# Altium schematic sheet YYB_2016.SchDoc
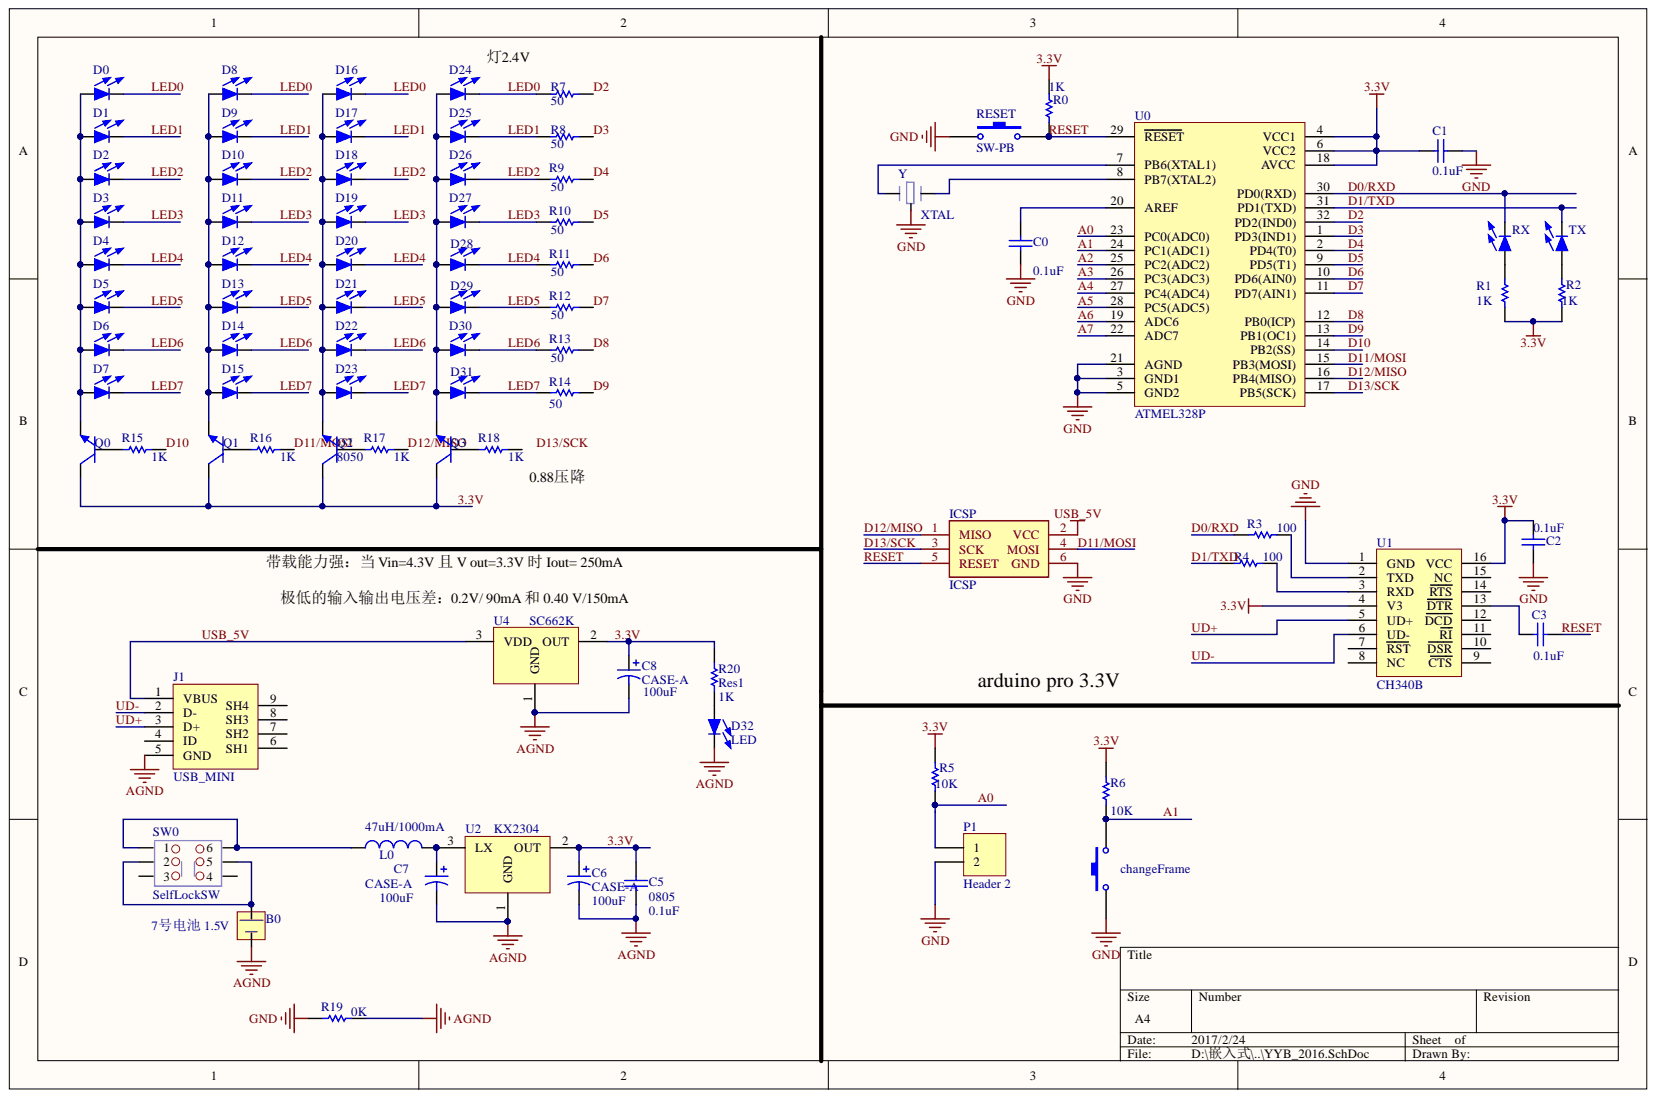
[diagram p0: YYB_2016.SchDoc]

## Parts
B0  7号电池 1.5V  [BAT]  (value not drawn)
C0  value 0.1uF  [6-0805_N]
C1  value 0.1uF  [6-0805_N]  (hidden comment: Cap)
C2  value 0.1uF  [6-0805_N]  (hidden comment: Cap)
C3  value 0.1uF  [6-0805_N]  (hidden comment: Cap)
C5  0805  value 0.1uF  [6-0805_N]
C6  CASE-A  value 100uF  [CASE-A_3216]
C7  CASE-A  value 100uF  [CASE-A_3216]
C8  CASE-A  value 100uF  [CASE-A_3216]
changeFrame  SW-PB  [KEY_SODFL_2-Leads]  (value not drawn)
D0  (no value)  [LED_3528]
D1  (no value)  [LED_3528]
D2  (no value)  [LED_3528]
D3  (no value)  [LED_3528]
D4  (no value)  [LED_3528]
D5  (no value)  [LED_3528]
D6  (no value)  [LED_3528]
D7  (no value)  [LED_3528]
D8  (no value)  [LED_3528]
D9  (no value)  [LED_3528]
D10  (no value)  [LED_3528]
D11  (no value)  [LED_3528]
D12  (no value)  [LED_3528]
D13  (no value)  [LED_3528]
D14  (no value)  [LED_3528]
D15  (no value)  [LED_3528]
D16  (no value)  [LED_3528]
D17  (no value)  [LED_3528]
D18  (no value)  [LED_3528]
D19  (no value)  [LED_3528]
D20  (no value)  [LED_3528]
D21  (no value)  [LED_3528]
D22  (no value)  [LED_3528]
D23  (no value)  [LED_3528]
D24  (no value)  [LED_3528]
D25  (no value)  [LED_3528]
D26  (no value)  [LED_3528]
D27  (no value)  [LED_3528]
D28  (no value)  [LED_3528]
D29  (no value)  [LED_3528]
D30  (no value)  [LED_3528]
D31  (no value)  [LED_3528]
D32  LED  [LED_0805]
ICSP  ICSP  [HDR2X3]
J1  USB_MINI  [MICRO_USB]
L0  value 47uH/1000mA  [0402-A]  (hidden comment: Inductor)
P1  Header 2  [HDR1X2]
Q0  transistor  [SOT-23]  (value not drawn)
Q1  transistor  [SOT-23]  (value not drawn)
Q2  8050  [SOT-23]
Q3  transistor  [SOT-23]  (value not drawn)
R0  value 1K  [6-0805_N]
R1  value 1K  [6-0805_N]  (hidden comment: Res1)
R2  value 1K  [6-0805_N]  (hidden comment: Res1)
R3  value 100  [6-0805_N]  (hidden comment: Res1)
R4  value 100  [6-0805_N]  (hidden comment: Res1)
R5  value 10K  [6-0805_N]  (hidden comment: Res1)
R6  value 10K  [6-0805_N]  (hidden comment: Res1)
R7  value 50  [6-0805_N]  (hidden comment: Res1)
R8  value 50  [6-0805_N]  (hidden comment: Res1)
R9  value 50  [6-0805_N]  (hidden comment: Res1)
R10  value 50  [6-0805_N]  (hidden comment: Res1)
R11  value 50  [6-0805_N]  (hidden comment: Res1)
R12  value 50  [6-0805_N]  (hidden comment: Res1)
R13  value 50  [6-0805_N]  (hidden comment: Res1)
R14  value 50  [6-0805_N]  (hidden comment: Res1)
R15  value 1K  [6-0805_N]  (hidden comment: Res1)
R16  value 1K  [6-0805_N]  (hidden comment: Res1)
R17  value 1K  [6-0805_N]  (hidden comment: Res1)
R18  value 1K  [6-0805_N]  (hidden comment: Res1)
R19  value 0K  [6-0805_N]  (hidden comment: Res1)
R20  Res1  value 1K  [6-0805_N]
RESET  SW-PB  [KEY_SODFL_2-Leads]
RX  (no value)  [LED_0805]
SW0  SelfLockSW  [KEY_ZS_8*8]
TX  (no value)  [LED_0805]
U0  ATMEL328P  [TQFP 32]
U1  CH340B  [SOP16]
U2  KX2304  [SOT89N]
U4  SC662K  [SOT-23]
Y  XTAL  [XTAL]

## Nets
AGND: B0.2 C5.1 C6.2 C7.2 C8.2 D32.2 J1.5(GND) R19.2 U2.1 U4.1
GND: C0.1 C1.1 C2.1 changeFrame.1 ICSP.6(GND) P1.2 R19.1 RESET.1 U0.3(GND1) U0.5(GND2) U0.21(AGND) U1.1(GND) Y.2
3.3V: C1.2 C2.2 C5.2 C6.1 C8.1 Q0.3 Q1.3 Q2.3 Q3.3 R0.1 R1.1 R2.1 R5.2 R6.2 R20.2 U0.4(VCC1) U0.6(VCC2) U0.18(AVCC) U1.4(V3) U1.16(VCC) U2.2 U4.2
A0: P1.1 R5.1 U0.23(PC0(ADC0))
A1: changeFrame.2 R6.1 U0.24(PC1(ADC1))
A2: U0.25(PC2(ADC2))
A3: U0.26(PC3(ADC3))
A4: U0.27(PC4(ADC4))
A5: U0.28(PC5(ADC5))
A6: U0.19(ADC6)
A7: U0.22(ADC7)
D0/RXD: R3.1 RX.2 U0.30(PD0(RXD))
D1/TXD: R4.1 TX.2 U0.31(PD1(TXD))
D2: R7.2 U0.32(PD2(IND0))
D3: R8.2 U0.1(PD3(IND1))
D4: R9.2 U0.2(PD4(T0))
D5: R10.2 U0.9(PD5(T1))
D6: R11.2 U0.10(PD6(AIN0))
D7: R12.2 U0.11(PD7(AIN1))
D8: R13.2 U0.12(PB0(ICP))
D9: R14.2 U0.13(PB1(OC1))
D10: R15.2 U0.14(PB2(SS))
D11/MOSI: ICSP.4(MOSI) R16.2 U0.15(PB3(MOSI))
D12/MISO: ICSP.1(MISO) R17.2 U0.16(PB4(MISO))
D13/SCK: ICSP.3(SCK) U0.17(PB5(SCK))
LED0: D0.2 D8.2 D16.2 D24.2 R7.1
LED1: D1.2 D9.2 D17.2 D25.2 R8.1
LED2: D2.2 D10.2 D18.2 D26.2 R9.1
LED3: D3.2 D11.2 D19.2 D27.2 R10.1
LED4: D4.2 D12.2 D20.2 D28.2 R11.1
LED5: D5.2 D13.2 D21.2 D29.2 R12.1
LED6: D6.2 D14.2 D22.2 D30.2 R13.1
LED7: D7.2 D15.2 D23.2 D31.2 R14.1
RESET: C3.2 ICSP.5(RESET) R0.2 RESET.2 U0.29(RESET)
UD+: J1.3(D+) U1.5(UD+)
UD-: J1.2(D-) U1.6(UD-)
USB_5V: ICSP.2(VCC) J1.1(VBUS) U4.3
NetB0_1: B0.1 SW0.2 SW0.5
NetC0_2: C0.2 U0.20(AREF)
NetC3_1: C3.1 U1.13(DTR)
NetC7_1: C7.1 L0.2 U2.3
NetD0_1: D0.1 D1.1 D2.1 D3.1 D4.1 D5.1 D6.1 D7.1 Q0.2
NetD8_1: D8.1 D9.1 D10.1 D11.1 D12.1 D13.1 D14.1 D15.1 Q1.2
NetD16_1: D16.1 D17.1 D18.1 D19.1 D20.1 D21.1 D22.1 D23.1 Q2.2
NetD24_1: D24.1 D25.1 D26.1 D27.1 D28.1 D29.1 D30.1 D31.1 Q3.2
NetD32_1: D32.1 R20.1
NetL0_1: L0.1 SW0.1 SW0.6
NetQ0_1: Q0.1 R15.1
NetQ1_1: Q1.1 R16.1
NetQ2_1: Q2.1 R17.1
NetQ3_1: Q3.1 R18.1
NetR1_2: R1.2 RX.1
NetR2_2: R2.2 TX.1
NetR3_2: R3.2 U1.2(TXD)
NetR4_2: R4.2 U1.3(RXD)
NetU0_7: U0.7(PB6(XTAL1)) Y.1
NetU0_8: U0.8(PB7(XTAL2)) Y.3

Not connected: J1 4(ID) 6(SH1) 7(SH2) 8(SH3) 9(SH4); R18 2; SW0 3 4; U1 7(RST) 8(NC) 9(CTS) 10(DSR) 11(RI) 12(DCD) 14(RTS) 15(NC)

## Library data of the parts
B0 = Battery: Component Kind: Standard; Library Name: aistlab.SchLib
C0-C3 C5 = Cap: Capacitor; Component Kind: Standard; LatestRevisionDate: 17-Jul-2002; LatestRevisionNote: Re-released for DXP Platform.; Library Name: Miscellaneous Devices.IntLib; PackageDescription: Radial Cap, Thru-Hole; 2 Leads; 0.3 in Pin Spacing; PackageReference: RAD-0.3; Published: 8-Jun-2000; Signal Integrity: Cap; Simulation: CAP; Publisher: Altium Limited
C6-C8 = Cap Pol1: Polarized Capacitor (Radial); Component Kind: Standard; LatestRevisionDate: 17-Jul-2002; LatestRevisionNote: Re-released for DXP Platform.; Library Name: Miscellaneous Devices.IntLib; PackageDescription: Polarized Capacitor (Radial); 2 Leads; PackageReference: RB7.6-15; Published: 8-Jun-2000; Signal Integrity: Cap Pol; Simulation: CAP; Publisher: Altium Limited
changeFrame RESET = SW-PB: Switch; Component Kind: Standard; LatestRevisionDate: 17-Jul-2002; LatestRevisionNote: Re-released for DXP Platform.; Library Name: Miscellaneous Devices.IntLib; PackageDescription: Switch; 2 Leads; PackageReference: SPST-2; Published: 24-Mar-1999; Publisher: Altium Limited
D0-D31 RX TX = LED: Component Kind: Standard; Library Name: aistlab.SchLib
L0 = Inductor: Code_IEC: 1005; Code_JEITA: 0402; Component Kind: Standard; Description: Inductor; LatestRevisionDate: 29-May-2009; LatestRevisionNote: IPC-7351 Footprint Added.; Library Name: Miscellaneous Devices.IntLib; PackageDescription: Chip Inductor; PackageReference: 0402-A; Published: 8-Jun-2000; Signal Integrity: Inductor; Simulation: INDUCTOR; Publisher: Altium Limited
P1 = Header 2: Header, 2-Pin; Component Kind: Standard; LatestRevisionDate: 17-Jul-2002; LatestRevisionNote: Re-released for DXP Platform.; Library Name: Miscellaneous Connectors.IntLib; Signal Integrity: Connector; Publisher: Altium Limited
Q2 = transistor: Component Kind: Standard; Library Name: aistlab.SchLib
R0-R20 = Res1: Resistor; Component Kind: Standard; LatestRevisionDate: 17-Jul-2002; LatestRevisionNote: Re-released for DXP Platform.; Library Name: Miscellaneous Devices.IntLib; PackageDescription: Axial Device, Thru-Hole; 2 Leads; 0.3 in Pin Spacing; PackageReference: AXIAL-0.3; Published: 8-Jun-2000; Signal Integrity: Res; Simulation: RESISTOR; Publisher: Altium Limited
SW0 = SelfLockSwitch: 自锁开关; Component Kind: Standard; Library Name: aistlab.SchLib
U0 = ATMEL-328P: Component Kind: Standard; Library Name: aistlab.SchLib
U1 = CH340B: usb to uart; Component Kind: Standard; Library Name: aistlab.SchLib
U4 = SC662k-3.3V: 3.3V 稳压芯片; Component Kind: Standard; Library Name: aistlab.SchLib
D32 = LED: Component Kind: Standard; Library Name: aistlab.SchLib
ICSP = ICSP: Component Kind: Standard; Library Name: aistlab.SchLib
J1 = USB_MINI: Component Kind: Standard; Library Name: YYB-Schlib1.SchLib
Q0 Q1 Q3 = transistor: Component Kind: Standard; Library Name: aistlab.SchLib
U2 = KX2304: Component Kind: Standard; Library Name: aistlab.SchLib
Y = XTAL: Component Kind: Standard; Library Name: aistlab.SchLib
